# Altium schematic sheet 底板原理图1.SchDoc
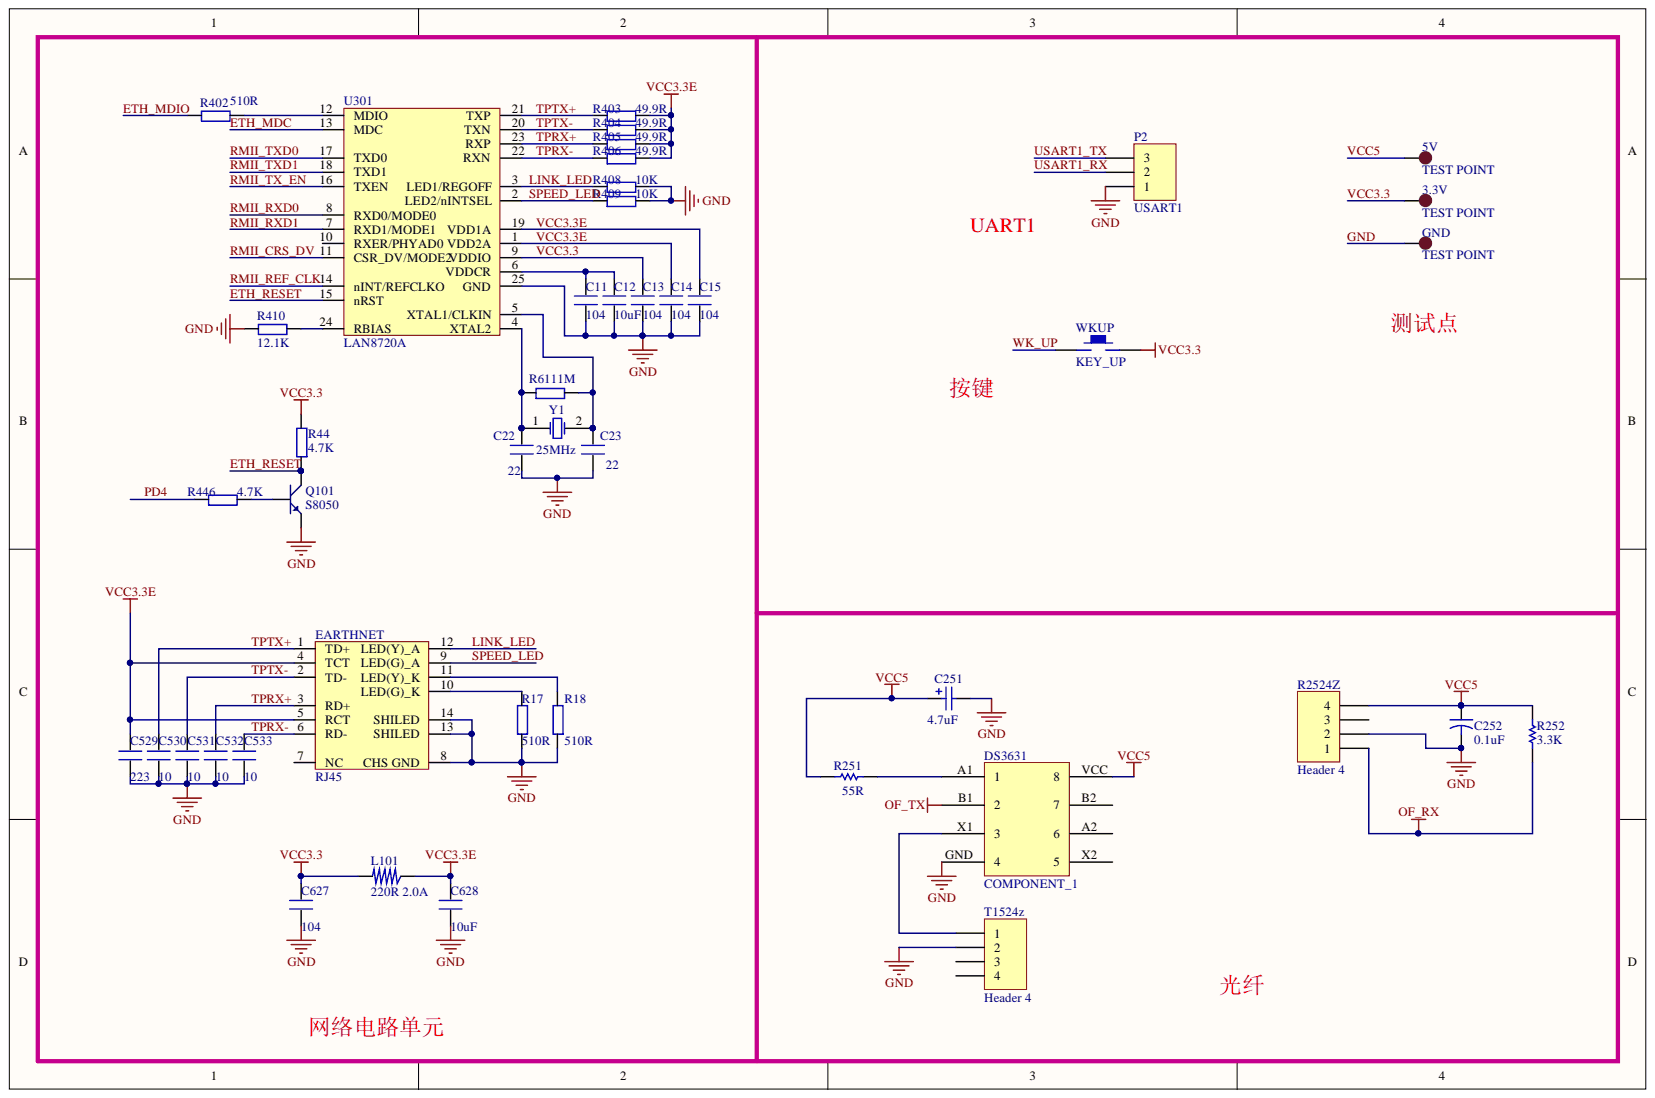
[diagram p0: 底板原理图1.SchDoc]

## Parts
3.3V  TEST POINT  [TP-1.5]
5V  TEST POINT  [TP-1.5]
C11  104  [0603P]
C12  10uF  [0805]
C13  104  [0603P]
C14  104  [0603P]
C15  104  [0603P]
C22  22  [0603P]
C23  22  [0603P]
C251  value 4.7uF  [POLAR0.8]  (hidden comment: Cap Pol2)
C252  value 0.1uF  [CAPR5-4X5]  (hidden comment: Cap2)
C529  223  [0603P]
C530  10  [0603P]
C531  10  [0603P]
C532  10  [0603P]
C533  10  [0603P]
C627  104  [0603P]
C628  10uF  [0805]
DS3631  COMPONENT_1
EARTHNET  RJ45  [HR911105A]
GND  TEST POINT  [TP-1.5]
L101  220R 2.0A  [0603P]
P2  USART1  [HDR1X3]
Q101  S8050  [SOT-23R]
R17  510R  [0603P]
R18  510R  [0603P]
R44  4.7K  [0603P]
R251  value 55R  [AXIAL-0.3]  (hidden comment: Res1)
R252  value 3.3K  [AXIAL-0.3]  (hidden comment: Res1)
R402  510R  [0603P]
R403  49.9R  [0603P]
R404  49.9R  [0603P]
R405  49.9R  [0603P]
R406  49.9R  [0603P]
R408  10K  [0603P]
R409  10K  [0603P]
R410  12.1K  [0603P]
R446  4.7K  [0603P]
R611  1M  [0603P]  (value not drawn)
R2524Z  Header 4  [HDR1X4]
T1524z  Header 4  [HDR1X4]
U301  LAN8720A  [QFN24]
WKUP  KEY_UP  [KEY_SOP3625]
Y1  25MHz  [XTAL_US]

## Nets
OF_RX: R252.1 R2524Z.1
OF_TX: DS3631.B1
ETH_MDC: U301.13(MDC)
ETH_MDIO: R402.1
ETH_RESET: Q101.3 R44.1 U301.15(nRST)
GND: C11.1 C12.1 C13.1 C14.1 C15.1 C22.1 C23.1 C251.2 C252.2 C529.1 C530.1 C531.1 C532.1 C533.1 C627.1 C628.1 DS3631.GND EARTHNET.8(CHS_GND) EARTHNET.13(SHILED) EARTHNET.14(SHILED) GND.1 P2.1 Q101.1 R17.1 R18.1 R408.2 R409.2 R410.1 R2524Z.2 T1524z.2 U301.25(GND)
LINK_LED: EARTHNET.12(LED(Y)_A) R408.1 U301.3(LED1/REGOFF)
PD4: R446.1
RMII_CRS_DV: U301.11(CSR_DV/MODE2)
RMII_REF_CLK: U301.14(nINT/REFCLKO)
RMII_RXD0: U301.8(RXD0/MODE0)
RMII_RXD1: U301.7(RXD1/MODE1)
RMII_TX_EN: U301.16(TXEN)
RMII_TXD0: U301.17(TXD0)
RMII_TXD1: U301.18(TXD1)
SPEED_LED: EARTHNET.9(LED(G)_A) R409.1 U301.2(LED2/nINTSEL)
TPRX+: C532.2 EARTHNET.3(RD+) R405.1 U301.23(RXP)
TPRX-: C533.2 EARTHNET.6(RD-) R406.1 U301.22(RXN)
TPTX+: C530.2 EARTHNET.1(TD+) R403.1 U301.21(TXP)
TPTX-: C531.2 EARTHNET.2(TD-) R404.1 U301.20(TXN)
USART1_RX: P2.2
USART1_TX: P2.3
VCC3.3: 3.3V.1 C13.2 C627.2 L101.2 R44.2 U301.9(VDDIO) WKUP.2
VCC3.3E: C14.2 C15.2 C529.2 C628.2 EARTHNET.4(TCT) EARTHNET.5(RCT) L101.1 R403.2 R404.2 R405.2 R406.2 U301.1(VDD2A) U301.19(VDD1A)
VCC5: 5V.1 C251.1 C252.1 DS3631.VCC R251.1 R252.2 R2524Z.4
WK_UP: WKUP.1
NetC11_2: C11.2 C12.2 U301.6(VDDCR)
NetC22_2: C22.2 R611.1 U301.4(XTAL2) Y1.1
NetC23_2: C23.2 R611.2 U301.5(XTAL1/CLKIN) Y1.2
NetDS3631_A1: DS3631.A1 R251.2
NetDS3631_X1: DS3631.X1 T1524z.1
NetEARTHNET_10: EARTHNET.10(LED(G)_K) R17.2
NetEARTHNET_11: EARTHNET.11(LED(Y)_K) R18.2
NetQ101_2: Q101.2 R446.2
NetR402_2: R402.2 U301.12(MDIO)
NetR410_2: R410.2 U301.24(RBIAS)

Not connected: DS3631 A2 B2 X2; EARTHNET 7(NC); R2524Z 3; T1524z 3 4; U301 10(RXER/PHYAD0)

## Library data of the parts
3.3V 5V GND = TEST-POINT: 测试点; Component Kind: Standard; Library Name: STM32F429_CORE.SCHLIB
C11-C15 C22 C23 C529-C533 C627 C628 = C: Component Kind: Standard; Library Name: STM32F429_F746.SCHLIB
C251 = Cap Pol2: Polarized Capacitor (Axial); Component Kind: Standard; LatestRevisionDate: 17-Jul-2002; LatestRevisionNote: Re-released for DXP Platform.; Library Name: Miscellaneous Devices.IntLib; PackageDescription: Polarized Capacitor; 2 Leads; PackageReference: POLAR0.8; Published: 8-Jun-2000; Signal Integrity: Cap Pol; Simulation: CAP; Publisher: Altium Limited
C252 = Cap2: Capacitor; Component Kind: Standard; Library Name: Miscellaneous Devices.IntLib; PackageDescription: Capacitor; 2 Leads; PackageReference: CAPR5-4X5; Published: 23-Sep-2002; Signal Integrity: Cap; Simulation: CAP; Publisher: Altium Limited
EARTHNET = HR911105: Component Kind: Standard; Library Name: STM32F429_F746.SCHLIB
L101 = L_SOP: Component Kind: Standard; Library Name: STM32F429_F746.SCHLIB
P2 = Header 3: Header, 3-Pin; Component Kind: Standard; LatestRevisionDate: 17-Jul-2002; LatestRevisionNote: Re-released for DXP Platform.; Library Name: STM32F429_CORE.SCHLIB; Signal Integrity: Connector; Publisher: Altium Limited
Q101 = NPN: 8050/BCW846/BCW847; Component Kind: Standard; Library Name: STM32F429_F746.SCHLIB
R17 R18 R44 R402-R406 R408-R410 R446 R611 = R: Component Kind: Standard; Library Name: STM32F429_F746.SCHLIB
R251 R252 = Res1: Resistor; Component Kind: Standard; LatestRevisionDate: 17-Jul-2002; LatestRevisionNote: Re-released for DXP Platform.; Library Name: Miscellaneous Devices.IntLib; PackageDescription: Axial Device, Thru-Hole; 2 Leads; 0.3 in Pin Spacing; PackageReference: AXIAL-0.3; Published: 8-Jun-2000; Signal Integrity: Res; Simulation: RESISTOR; Publisher: Altium Limited
R2524Z T1524z = Header 4: Header, 4-Pin; Component Kind: Standard; LatestRevisionDate: 17-Jul-2002; LatestRevisionNote: Re-released for DXP Platform.; Library Name: Miscellaneous Connectors.IntLib; Signal Integrity: Connector; Publisher: Altium Limited
U301 = LAN8720: ETH PHY; Component Kind: Standard; Library Name: STM32F429_F746.SCHLIB
WKUP = KEY_M: Component Kind: Standard; Library Name: STM32F429_CORE.SCHLIB
Y1 = XTAL: Crystal Oscillator; Component Kind: Standard; LatestRevisionDate: 17-Jul-2002; LatestRevisionNote: Re-released for DXP Platform.; Library Name: STM32F429_F746.SCHLIB; Published: 8-Jun-2000; Simulation: XTAL; Publisher: Altium Limited
DS3631 = COMPONENT_1: Component Kind: Standard; Library Name: Schlib1.SchLib
